# Altium schematic sheet CAPPA-DELUXE-esp.SchDoc(CAPPA-DELUXE-esp)
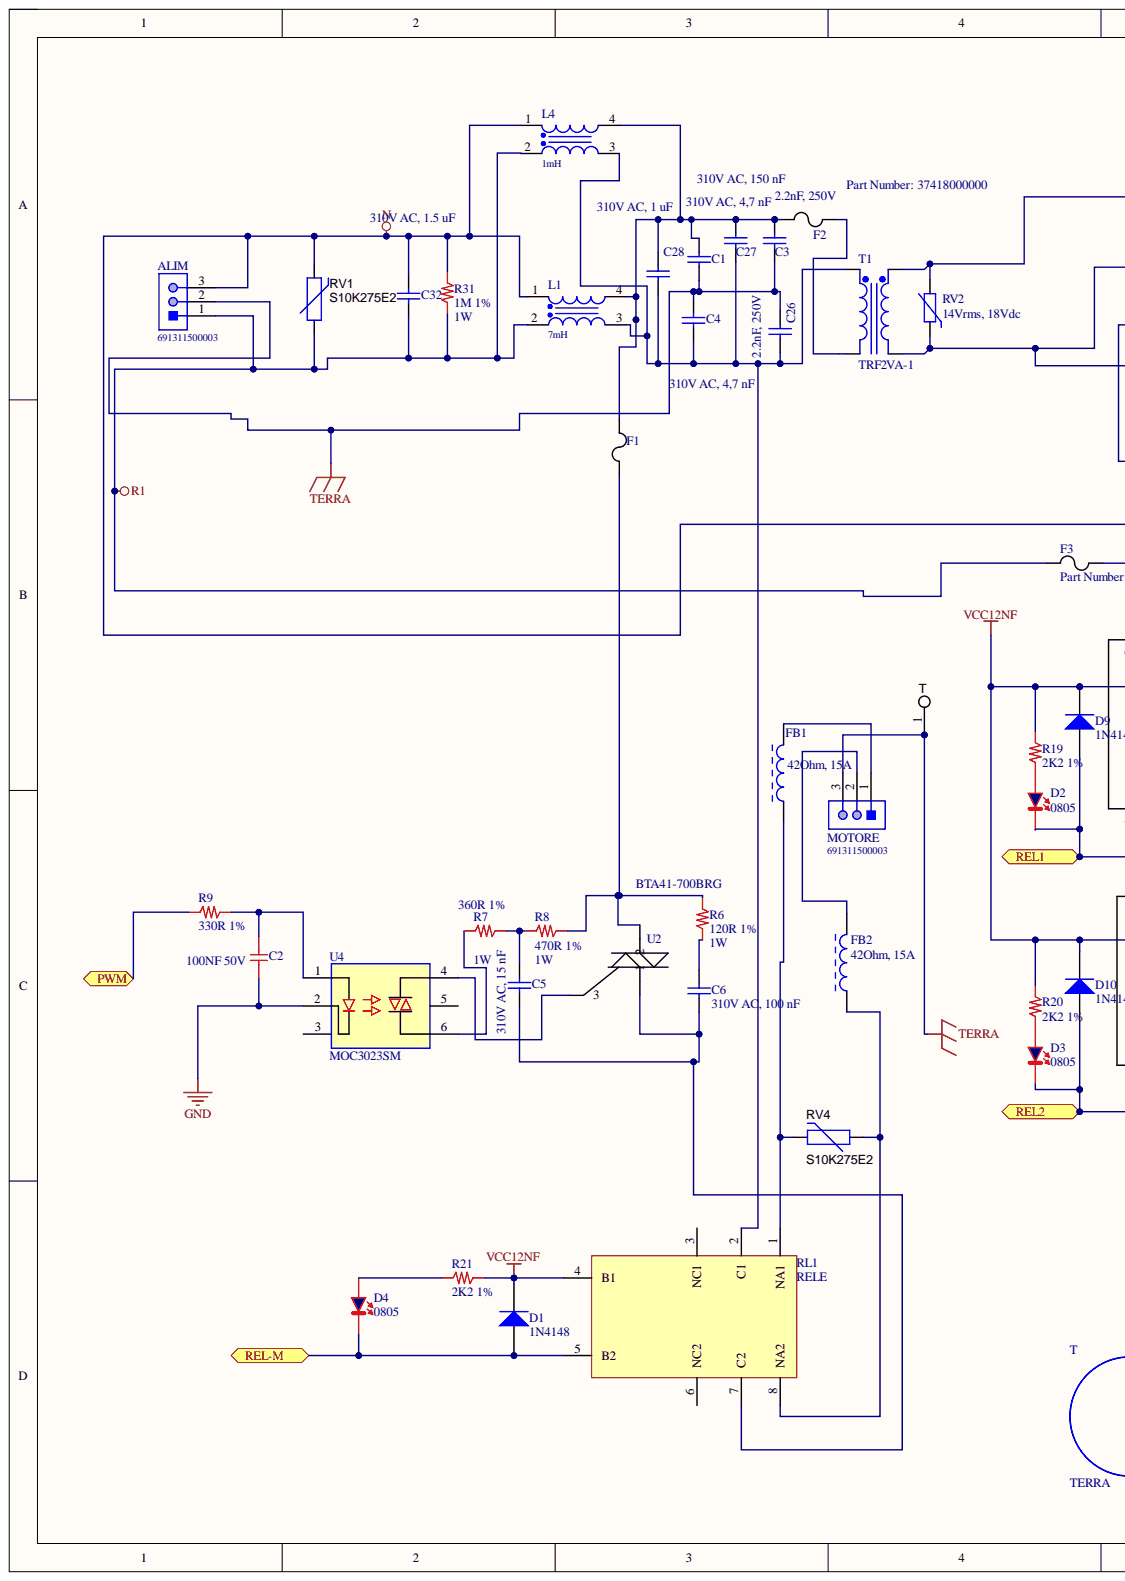
[diagram p0: CAPPA-DELUXE-esp.SchDoc, left]
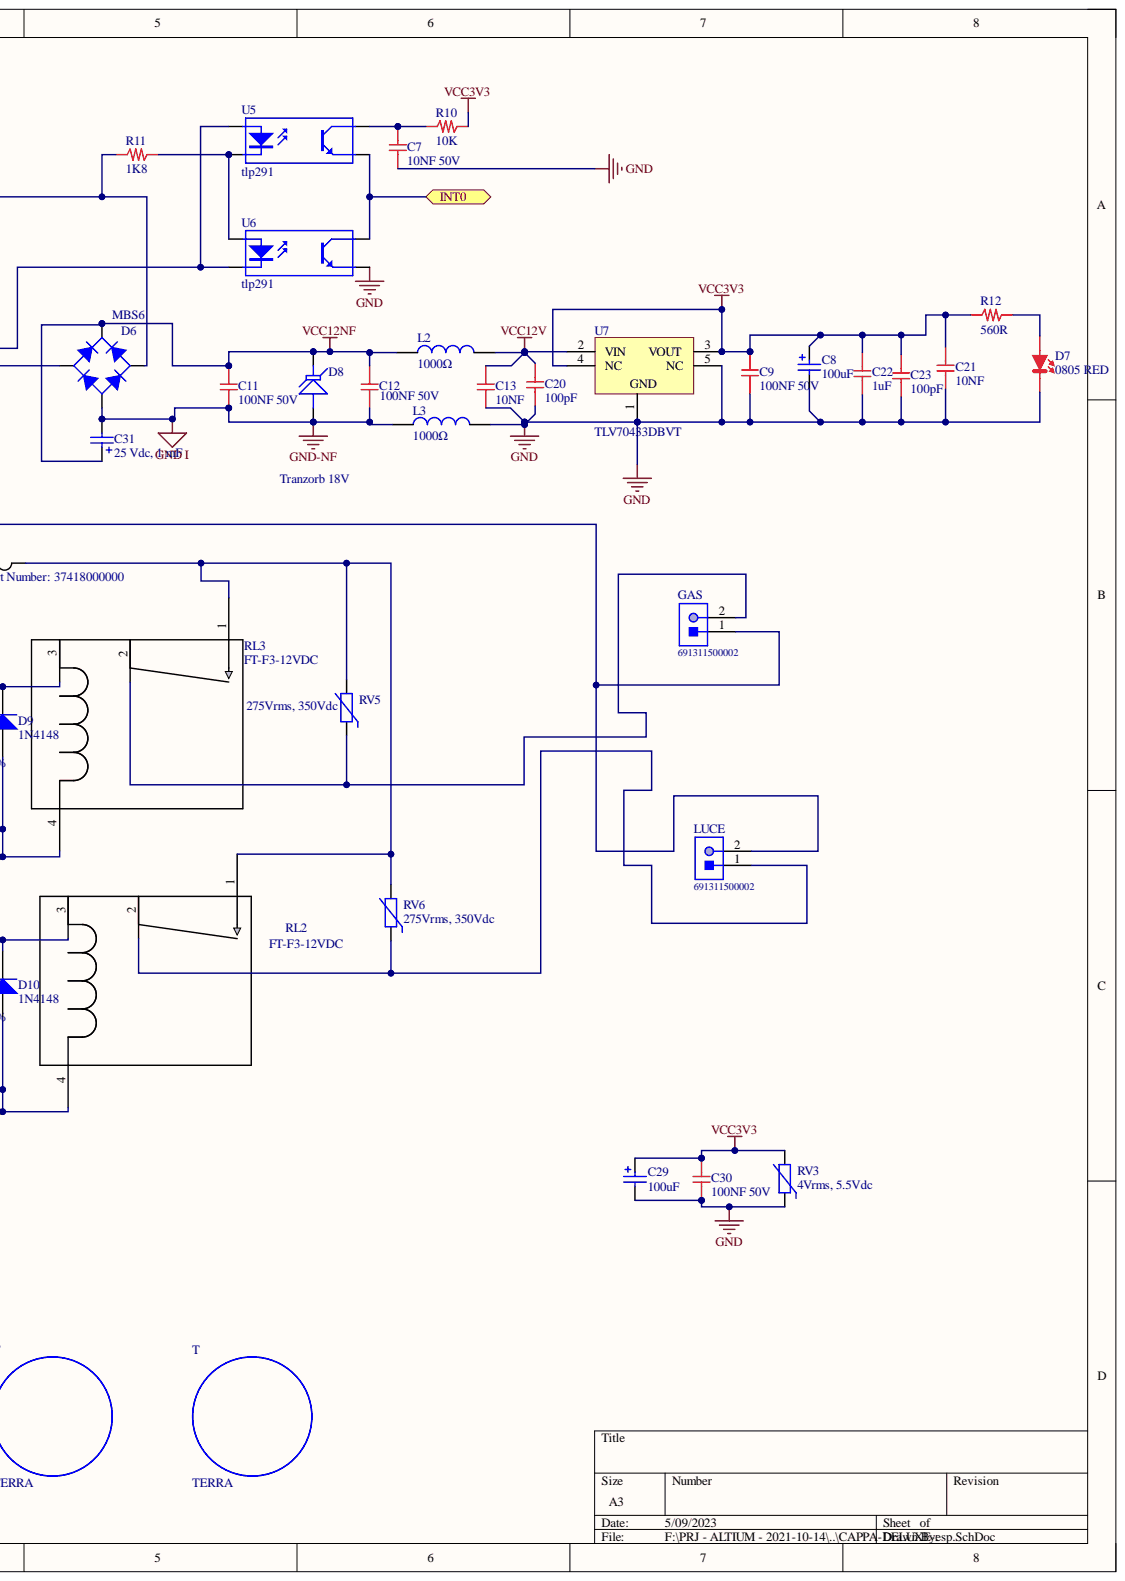
[diagram p1: CAPPA-DELUXE-esp.SchDoc, right]

## Parts
ALIM  691311500003  [691311500003]
C1  310V AC, 4,7 nF  [WCAP-FTXX_13x5x10]
C2  100NF 50V  [0603_c]
C3  2.2nF, 250V  [WCAP-CSSA_1812_H=2.5mm]
C4  310V AC, 4,7 nF  [WCAP-FTXX_13x5x10]
C5  310V AC, 15 nF  value 15 nF  [WCAP-FTXX_13x4.5x9.5]
C6  310V AC, 100 nF  value 100 nF  [WCAP-FTXX_13x7x13_CS]
C7  10NF 50V  [0603_c]
C8  value 100uF  [WCAP-ASLL_6.3x5.5]  (hidden comment: 865060343005)
C9  100NF 50V  [0603_c]
C11  100NF 50V  [0603_c]
C12  100NF 50V  [0603_c]
C13  10NF  [0603_c]
C20  100pF  [0603_c]
C21  10NF  [0603_c]
C22  1uF  [0603_c]
C23  100pF  [0603_c]
C26  2.2nF, 250V  [WCAP-CSSA_1812_H=2.5mm]
C27  310V AC, 150 nF  value 150 nF  [WCAP-FTXX_13x7x12]
C28  310V AC, 1 uF  value 1 uF  [WCAP-FTXX_26x11x20]
C29  value 100uF  [WCAP-ASLL_6.3x5.5]  (hidden comment: 865060343005)
C30  100NF 50V  [0603_c]
C31  25 Vdc, 1 mF  value 1 mF  [WCAP-ATLI_13x20x5]
C32  310V AC, 1.5 uF  value 1.5 uF  [WCAP-FTXX_26x10x21]
D1  1N4148  [1N4148]
D2  0805  [0805-BLU]
D3  0805  [0805-BLU]
D4  0805  [0805-BLU]
D6  MBS6  [HD01-T SOP4]
D7  0805 RED  [0805_Red]
D8  Tranzorb 18V  [DO-214AA]
D9  1N4148  [1N4148]
D10  1N4148  [1N4148]
F1  Part Number: 37418000000  [FUSE-S]
F2  Part Number: 37418000000  [FUSE-S]
F3  Part Number: 37418000000  [FUSE-S]
FB1  42Ohm, 15A  [WE-PBF_4030_7427934]
FB2  42Ohm, 15A  [WE-PBF_4030_7427934]
GAS  691311500002  [691311500002]
L1  7mH  [WE-CMBNC_Type L_7448040707]
L2  1000Ω  [WE-CBF_0805_High Current]
L3  1000Ω  [WE-CBF_0805_High Current]
L4  1mH  [WE-CMBNC_Type S]
LUCE  691311500002  [691311500002]
MOTORE  691311500003  [691311500003]
R6  120R 1%  Potenza: 1W  [2512_R]
R7  360R 1%  Potenza: 1W  [2512_R]
R8  470R 1%  [2512_R]
R9  330R 1%  [0805_R]
R10  10K  [0603_R]
R11  1K8  [1206_R]
R12  560R  [0603_R]
R19  2K2 1%  [0805_R]
R20  2K2 1%  [0805_R]
R21  2K2 1%  [0805_R]
R31  1M 1%  Potenza: 1W  [2512_R]
RL1  RELE  [FTR-F1R]
RL2  FT-F3-12VDC  [PAN_ALD]
RL3  FT-F3-12VDC  [PAN_ALD]
RV1  S10K275E2  [VAR_S10K275E2]
RV2  14Vrms, 18Vdc  [WE-VD_5mm_820551406]
RV3  4Vrms, 5.5Vdc  [WE-VS_0603]
RV4  S10K275E2  [VAR_S10K275E2]
RV5  275Vrms, 350Vdc  DC Operating Voltage: 350Vdc  [WE-VD_7mm_820572711]
RV6  275Vrms, 350Vdc  [WE-VD_7mm_820572711]
T  5012  [FASTOM-TERRA]  (value not drawn)
T  TERRA  [SIMBOLO-TERRA]
T  TERRA  [SIMBOLO-TERRA]
T1  TRF2VA-1  [2VA-1S]
U2  BTA41-700BRG  [TOP3_STM]
U4  MOC3023SM  [MOC3023SM]
U5  tlp291  [SO4_N]
U6  tlp291  [SO4_N]
U7  TLV70433DBVT  [SOT95P245X110-5N]

## Ports
INT0, PWM, REL1, REL2, REL-M

## Nets
GND: C2.2 C7.2 C8.2 C9.2 C13.2 C20.2 C21.2 C22.2 C23.2 C29.2 C30.2 D7.K L3.2 RV3.1 U4.2 U6.3 U7.1 U7.5(NC)
GND I: C11.1 C12.2 C31.2 D6.2 D8.2 L3.1
N: ALIM.3 C32.2 GAS.1 L1.1 L4.1 LUCE.2 R31.2 RV1.2
R1: ALIM.1 C32.1 F3.1 L1.2 L4.2 R31.1 RV1.1
TERRA: ALIM.2 C1.1 C3.1 C4.2 C26.2 MOTORE.3 T.1
VCC3V3: C8.1 C9.1 C21.1 C22.1 C23.1 C29.1 C30.1 R10.2 R12.1 RV3.2 U7.3(VOUT) U7.4(NC)
VCC12NF: C11.2 C12.1 C31.1 D1.2 D6.1 D8.1 D9.2 D10.2 L2.1 R19.2 R20.1 R21.2 RL1.4(B1) RL2.3 RL3.3
VCC12V: C13.1 C20.1 L2.2 U7.2(VIN)
INT0: U5.3 U6.4 ; port INT0
PWM: R9.1 ; port PWM
REL1: D2.K D9.1 RL3.4 ; port REL1
REL2: D3.K D10.1 RL2.4 ; port REL2
REL-M: D1.1 D4.K RL1.5(B2) ; port REL-M
NetC1_2: C1.2 C3.2 C27.2 C28.2 F1.1 F2.1 L1.4 L4.4
NetC2_1: C2.1 R9.2 U4.1
NetC4_1: C4.1 C26.1 C27.1 C28.1 L1.3 L4.3 RL1.2(C1) T1.1
NetC5_1: C5.1 C6.1 RL1.7(C2) U2.1
NetC5_2: C5.2 R7.2 R8.1
NetC6_2: C6.2 R6.2
NetC7_1: C7.1 R10.1 U5.4
NetD2_A: D2.A R19.1
NetD3_A: D3.A R20.2
NetD4_A: D4.A R21.1
NetD6_3: D6.3 R11.1 RV2.2 T1.4
NetD6_4: D6.4 RV2.1 T1.3 U5.1 U6.2
NetD7_A: D7.A R12.2
NetF1_2: F1.2 R6.1 R8.2 U2.2
NetF2_2: F2.2 T1.2
NetF3_2: F3.2 RL2.1 RL3.1 RV5.2 RV6.2
NetFB1_1: FB1.1 RL1.1(NA1) RV4.2
NetFB1_2: FB1.2 MOTORE.1
NetFB2_1: FB2.1 RL1.8(NA2) RV4.1
NetFB2_2: FB2.2 MOTORE.2
NetGAS_2: GAS.2 RL3.2 RV5.1
NetLUCE_1: LUCE.1 RL2.2 RV6.1
NetR7_1: R7.1 U4.6
NetR11_2: R11.2 U5.2 U6.1
NetU2_3: U2.3 U4.4

Not connected: RL1 3(NC1) 6(NC2); U4 3 5

## Library data of the parts
ALIM MOTORE = 691311500003: Serie 311 - 5.08mm Open Vertical PCB Header WR-TBL, 3 pin; Gender: Male; Pin Number: 3; Component Kind: Standard; ComponentLink1Description: Manufacturer; ComponentLink1URL: http://www.we-online.de; ComponentLink2Description: Datasheet; ComponentLink2URL: http://katalog.we-online.de/em/datasheet/6913115000xx.pdf; Library Name: Pluggable PCB System WR-TBL_5.08 mm.SchLib; Mounting Technology: THT; Operating Temperature: -40 to 105 degC; Packaging: Box; Part Number: 691311500003; Pitch: 5.08 mm; Published Date: July 2018; Publisher: Wurth Elektronik
C1 C4 = 890334023002: WCAP-FTXX Film Capacitors, 13x5x10mm, 310V AC, 4,7 nF CLASSE-Y; Rated Voltage: 310V AC; Value: 5.6 nF; Component Kind: Standard; ComponentLink1Description: Manufacturer; ComponentLink1URL: http://www.we-online.de; ComponentLink2Description: Datasheet; ComponentLink2URL: http://katalog.we-online.de/pbs/datasheet/890334023002.pdf; Library Name: WCAP-FTXX.SchLib; Operating Temperature: -40 °C to +105 °C; Part Number: 890334023002; Published Date: Sep. 2017; Size: 13x5x10mm; Publisher: Wurth Elektronik
C2 C7 C9 C11 C12 C30 = 100NF 50V: Cap X7R 100nF 50V Flex Terminal; Cod. Interno: COCS50665050104; Cod. Produttore: CL10B104KB8SFNC; Produttore: Samsung; Cod. DigiKey: 1276-1936-2-ND; Component Kind: Standard; Library Name: CapSMD0603.SchLib
C3 C26 = 885362211015: WCAP-CSSA X&Y Safety Ceramic Capacitors, size 1812, 2.2nF, 250VAC; Ceramic Type: X7R; Dissipation Factor: 2.5%; Isolation Resistance: 10GOhm; Safety Class: X2; Technical Reference: X7R1812222KB50IFCT10000; Capacitance: 2.2nF; Component Kind: Standard; ComponentLink1Description: Manufacturer; ComponentLink1URL: http://www.we-online.de; ComponentLink2Description: Datasheet; ComponentLink2URL: https://katalog.we-online.com/pbs/datasheet/885362211015.pdf; Height: 2.5mm +/-0.2mm; Library Name: WCAP-CSSA_1812.SchLib; Mounting Technology: SMD; Operating Temperature: -55 to +125degC; Packaging: Tape and Reel; Part Number: 885362211015; Published Date: August 2019; Rated Voltage: 250VAC; Size: 1812; Publisher: Wurth Elektronik
C5 = 890334023008: WCAP-FTXX Film Capacitors, 13x4.5x9.5mm, 310V AC, 15 nF; Rated Voltage: 310V AC; Component Kind: Standard; ComponentLink1Description: Manufacturer; ComponentLink1URL: http://www.we-online.de; ComponentLink2Description: Datasheet; ComponentLink2URL: http://katalog.we-online.de/pbs/datasheet/890334023008.pdf; Library Name: WCAP-FTXX.SchLib; Operating Temperature: -40 °C to +105 °C; Part Number: 890334023008; Published Date: Sep. 2017; Size: 13x4.5x9.5mm; Publisher: Wurth Elektronik
C6 = 890334023023CS: WCAP-FTXX Film Capacitors, 13x7x13mm, 310V AC, 100 nF; Rated Voltage: 310V AC; Value: 100 nF; Component Kind: Standard; ComponentLink1Description: Manufacturer; ComponentLink1URL: http://www.we-online.de; ComponentLink2Description: Datasheet; ComponentLink2URL: http://katalog.we-online.de/pbs/datasheet/890334023023CS.pdf; Library Name: WCAP-FTXX.SchLib; Operating Temperature: -40 °C to +105 °C; Part Number: 890334023023CS; Published Date: Sep. 2017; Size: 13x7x13mm; Publisher: Wurth Elektronik
C8 C29 = 865060343005: WCAP-ASLL Aluminum Electrolytic Capacitors, size Ф6.3x5.5mm, 16V DC, 100uF; Rated Voltage: 16V DC; Component Kind: Standard; ComponentLink1Description: Manufacturer; ComponentLink1URL: http://www.we-online.de; ComponentLink2Description: Datasheet; ComponentLink2URL: http://katalog.we-online.de/pbs/datasheet/865060343005.pdf; Library Name: WCAP-ASLL.SchLib; Life Cycle: 2000h; Operating Temperature: -55 °C up to +105 °C; Part Number: 865060343005; Published Date: June 2017; Size: Ф6.3x5.5mm; Publisher: Wurth Elektronik
C13 C21 = 10NF 50V: Cap X7R 10nF 50V; Cod. Interno: COCS 556655000103; Cod. Produttore: CL05B103KB5NNNC; Produttore: Samsung; Cod. DigiKey: 1276-1028-2-ND; Component Kind: Standard; Library Name: CapSMD0603.SchLib
C20 C23 = 100pF 50V: Cap X7R 100pF 50V; Cod. Interno: COCS??; Cod. Produttore: ??; Component Kind: Standard; Library Name: CapSMD0603.SchLib; Produttore: ??
C22 = 2.2uF 10V: 2.2 µF, 10 V, ± 10%, X5R 0603; Cod. Interno: COCS??; Cod. Produttore: 0603X225K100CT; Costo 100: 0,0436; Costo 1000: 0,0183; Produttore: WALSIN; Component Kind: Standard; ComponentLink1Description: FARNELL; ComponentLink1URL: http://it.farnell.com/walsin/0603x225k100ct/cond-mlcc-x5r-2-2uf-10v-0603-bob/dp/2495422?st=2.2uf; Library Name: CapSMD0603.SchLib
C27 = 890334023025: WCAP-FTXX Film Capacitors, 13x7x12mm, 310V AC, 150 nF; Rated Voltage: 310V AC; Value: 150 nF; Component Kind: Standard; ComponentLink1Description: Manufacturer; ComponentLink1URL: http://www.we-online.de; ComponentLink2Description: Datasheet; ComponentLink2URL: http://katalog.we-online.de/pbs/datasheet/890334023025.pdf; Library Name: WCAP-FTXX.SchLib; Operating Temperature: -40 °C to +105 °C; Part Number: 890334023025; Published Date: Sep. 2017; Size: 13x7x12mm; Publisher: Wurth Elektronik
C28 = 890334026027: WCAP-FTXX Film Capacitors, 26x11x20mm, 310V AC, 1 uF; Rated Voltage: 310V AC; Component Kind: Standard; ComponentLink1Description: Manufacturer; ComponentLink1URL: http://www.we-online.de; ComponentLink2Description: Datasheet; ComponentLink2URL: http://katalog.we-online.de/pbs/datasheet/890334026027.pdf; Library Name: WCAP-FTXX.SchLib; Operating Temperature: -40 °C to +105 °C; Part Number: 890334026027; Published Date: Sep. 2017; Size: 26x11x20mm; Publisher: Wurth Elektronik
C31 = 860080478020: WCAP-ATLI Aluminum Electrolytic Capacitors, 13x20mm, 25 Vdc, 1 mF; Rated Voltage: 25 Vdc; Component Kind: Standard; ComponentLink1Description: Manufacturer; ComponentLink1URL: http://www.we-online.de; ComponentLink2Description: Datasheet; ComponentLink2URL: http://katalog.we-online.com/pbs/datasheet/860080478020.pdf; Library Name: WCAP-ATLI.SchLib; Life Cycle: 5000h; Operating Temperature: -55 °C up to +105 °C; Part Number: 860080478020; Published Date: Sep. 2017; Size: 13x20mm; Publisher: Wurth Elektronik
C32 = 890334026030: WCAP-FTXX Film Capacitors, 26x10x21mm, 310V AC, 1.5 uF; Rated Voltage: 310V AC; Value: 1.5 uF; Component Kind: Standard; ComponentLink1Description: Manufacturer; ComponentLink1URL: http://www.we-online.de; ComponentLink2Description: Datasheet; ComponentLink2URL: http://katalog.we-online.de/pbs/datasheet/890334026030.pdf; Library Name: WCAP-FTXX.SchLib; Operating Temperature: -40 °C to +105 °C; Part Number: 890334026030; Published Date: Sep. 2017; Size: 26x10x21mm; Publisher: Wurth Elektronik
D1 D9 D10 = 1N4148: High Conductance Fast Diode; Code_JEDEC: DO-204-AH; Component Kind: Standard; ComponentLink1Description: Manufacturer Link; ComponentLink1URL: http://www.fairchildsemi.com/; ComponentLink2Description: Datasheet; ComponentLink2URL: http://www.fairchildsemi.com/ds/1N%2F1N4148.pdf; DatasheetVersion: 1997; LatestRevisionDate: 17-Jul-2002; LatestRevisionNote: Re-released for DXP Platform.; PackageDescription: Diode, Axial; Body 3.8 x 1.9 mm (LxDia. max), Lead Dia. 0.53 mm (max); PackageReference: DO-35; Published: 8-Jun-2000; Library Name: HSW.SchLib; Publisher: Altium Limited
D2-D4 = LED BLU 0805: Cod. Produttore: KPTR-3216QBC-D; Luminosità: 100mcd 20mA; Produttore: kingbright; Vf(typ): 3.3V; Component Kind: Standard; Description: LED BLU 0805; Library Name: DiodiLED.SchLib
D6 = HD01-T: Full Wave Diode Bridge; Component Kind: Standard; Library Name: HSW.SchLib; Publisher: Altium Limited
D7 = LED_ROSSO_0805: LED Rosso 0805; Cod. Interno: LEDS 2AC080RS7500; Cod. Produttore: 150080RS75000; Luminosità: 150mcd; Produttore: WE; V: 2.0V; Component Kind: Standard; Library Name: DiodiLED.SchLib
D8 = Tranzorb: Transient Voltage Suppression (TVS) Diode; Component Kind: Standard; LatestRevisionDate: 17-Jul-2002; LatestRevisionNote: Re-released for DXP Platform.; PackageDescription: Axial Lead Diode; PackageReference: DO-7; Published: 24-Mar-1999; Library Name: HSW.SchLib; Publisher: Altium Limited
F1-F3 = FUSE-S: No Description Available; Built_By: EMA_UL_Team; Type: DEV; Vendor: Littelfuse Inc; Component Kind: Standard; Library Name: FUSE-S.SchLib; Manufacturer_Part_Number: 37418000000; RefDes: RefDes; Value: Value; Copyright: Copyright (C) 2018 Accelerated Designs. All rights reserved
FB1 FB2 = 7427934: WE-PBF Flat Wire High Current SMT Ferrite Bead, size 4030, 42Ohm, 15A; Manufacturer: Wurth Elektronik; DC Resistance max.: 0.4mOhm; Impedance @25MHz: 28Ohm; Type: Not glued; Component Kind: Standard; ComponentLink1Description: Manufacturer; ComponentLink1URL: http://www.we-online.de; ComponentLink2Description: Datasheet; ComponentLink2URL: https://www.we-online.com/catalog/datasheet/7427934.pdf; Height: 2.5mm; Impedance @100MHz: 42Ohm; Length: 4.8mm; Library Name: WE-PBF_4030.SchLib; Manufacturer Part Number: 7427934; Mounting Technology: SMT; Operating Temperature: -55 to +125degC; Packaging: Tape and Reel; Published Date: April 2020; Rated Current: 15A; Size: 4030; Width: 3mm
GAS LUCE = 691311500002: Serie 311 - 5.08mm Open Vertical PCB Header WR-TBL, 2 pin; Gender: Male; Pin Number: 2; Component Kind: Standard; ComponentLink1Description: Manufacturer; ComponentLink1URL: http://www.we-online.de; ComponentLink2Description: Datasheet; ComponentLink2URL: http://katalog.we-online.de/em/datasheet/6913115000xx.pdf; Library Name: Pluggable PCB System WR-TBL_5.08 mm.SchLib; Mounting Technology: THT; Operating Temperature: -40 to 105 degC; Packaging: Box; Part Number: 691311500002; Pitch: 5.08 mm; Published Date: July 2018; Publisher: Wurth Elektronik
L1 = 7448040707: WE-CMBNC Common Mode Power Line Choke Nanocrystalline, Type L, 7mH, 7A, 250VAC; Manufacturer: Wurth Elektronik; DC Resistance max.: 20mOhm; Insulation Test Voltage: 1500VAC; Component Kind: Standard; ComponentLink1Description: Manufacturer; ComponentLink1URL: http://www.we-online.de; ComponentLink2Description: Datasheet; ComponentLink2URL: https://www.we-online.com/catalog/datasheet/7448040707.pdf; Inductance: 7mH; Library Name: WE-CMBNC_Type L.SchLib; Manufacturer Part Number: 7448040707; Mounting Technology: THT; Operating Temperature: -40 to +125degC; Packaging: Carton; Published Date: April 2020; Rated Current: 7A; Rated Voltage: 250VAC; Size: Type L
L2 L3 = WE-CBF, 742792096: WE-CBF SMD EMI Suppression Ferrite Bead, 0805, 1000Ω; Type: High Current; Component Kind: Standard; ComponentLink1Description: Manufacturer; ComponentLink1URL: http://www.we-online.de; ComponentLink2Description: Datasheet; ComponentLink2URL: http://katalog.we-online.de/pbs/datasheet/742792096.pdf; Library Name: WE-CBF_0805.SchLib; PartNumber: 742792096; PublishedDate: Aug 2016; Size: 0805; Value: 1000Ω; Publisher: Wurth Elektronik
L4 = 7448027001: WE-CMBNC Common Mode Power Line Choke Nanocrystalline, Type S, 1mH, 7A, 250VAC; Manufacturer: Wurth Elektronik; DC Resistance max.: 6mOhm; Insulation Test Voltage: 1500VAC; Component Kind: Standard; ComponentLink1Description: Manufacturer; ComponentLink1URL: http://www.we-online.de; ComponentLink2Description: Datasheet; ComponentLink2URL: https://www.we-online.com/catalog/datasheet/7448027001.pdf; Inductance: 1mH; Library Name: WE-CMBNC_Type S.SchLib; Manufacturer Part Number: 7448027001; Mounting Technology: THT; Operating Temperature: -40 to +125degC; Packaging: Carton; Published Date: April 2020; Rated Current: 7A; Rated Voltage: 250VAC; Size: Type S
R6-R8 R31 = 120R 1% 1W: Res Thick Film 120R 1W 1%; Cod. Interno: RESS 4190310A1200; Cod. Produttore: CRCW2512120RFKEG; Produttore: Vishay Dale; Cod. DigiKey: 541-120AFCT-ND; Component Kind: Standard; Library Name: ResSMD2512.SchLib
  R8: Potenza: 1W
R9 = 300R 1%: Res Film Spesso 100mW 1M 1%; Cod. Interno: ??; Cod. Produttore: ERA6AEB301V; Produttore: Panasonic; V nominale: 100V; Cod. Farnell: 1670221; Component Kind: Standard; Library Name: ResSMD0805.SchLib; Potenza: 100mW
R10 = 10K: Res Film Spesso 1/16W 10K 5%; Cod. Interno: RESS4166556B0103??; Cod. Produttore: ??; Component Kind: Standard; Library Name: ResSMD0603.SchLib; Produttore: ??
R11 = 1K8: 1K8 1206 1/4W; Case: 1206; Component Kind: Standard; Library Name: ResSMD1206.SchLib
R12 = 560R: Res Film Spesso 1/16W 560R 5%; Cod. Interno: RESS 4166556B0561; Component Kind: Standard; Library Name: ResSMD0603.SchLib
R19-R21 = 2K2 1%: Res Film Spesso 0805 1/2W 2K2 1%; Cod. Interno: ??; Component Kind: Standard; Library Name: ResSMD0805.SchLib
RL1 = FTR-F1R: RELE 8A 2NA; Component Kind: Standard; Library Name: HSW.SchLib
RL2 RL3 = ALDP106W: No Description Available; Built_By: EMA_UL_Team; Vendor: Panasonic; Component Kind: Standard; Manufacturer_Part_Number: ALDP106W; RefDes: RefDes; Type: DEV; Value: Value; Copyright: Copyright (C) 2018 Accelerated Designs. All rights reserved; Library Name: FTR-F3.SchLib
RV1 RV4 = S10K275E2: Varistor 275V RMS 10MM Radial, TH; Manufacturer: EPCOS Inc; SymbolCreator: a0412235; Component Kind: Standard; ComponentLink1Description: Datasheet URL; ComponentLink1URL: http://www.epcos.com/inf/70/db/var_08/SIOV_Leaded_AdvanceD.pdf; LatestRevisionDate: 2013-02-24; MountType: TH; PackageReference: 10mm Radial; PartNumber: S10K275E2; RoHS: Y; Supplier 1: Digi-Key; Supplier Part Number 1: 495-1433-ND; SymbolVersion: 2012-06-04; Library Name: HSW.SchLib; Publisher: Texas Instruments
RV2 = 820551406: WE-VD Disk Varistor, size 5mm, 14Vrms, 18Vdc; (Channel) Input Capacitance: 1130pF; (Reverse) Breakdown Voltage: 22V; (Reverse) Peak Pulse Current: 100A; Clamping Voltage: 48V; Energy Absorbtion: 0.7J; Power Dissipation: 0.01W; AC Operating Voltage: 14Vrms; Component Kind: Standard; ComponentLink1Description: Manufacturer; ComponentLink1URL: http://www.we-online.de; ComponentLink2Description: Datasheet; ComponentLink2URL: https://katalog.we-online.com/pbs/datasheet/820551406.pdf; DC Operating Voltage: 18Vdc; Mounting Technology: THT; Operating Temperature: -40 to +85degC; Packaging: Tape and Reel; Part Number: 820551406; Published Date: March 2019; Size: 5mm; Library Name: MOV_5mm.SchLib; Publisher: Wurth Elektronik
RV3 = 82536040: WE-VS SMD Varistor, size 0603, 4Vrms, 5.5Vdc; Clamping Voltage: 21V; Energy Dissipation: 0.1J; Varistor Voltage: 8V; Withstanding Surge Current: 30A; AC Operating Voltage: 4Vrms; Component Kind: Standard; ComponentLink1Description: Manufacturer; ComponentLink1URL: http://www.we-online.de; ComponentLink2Description: Datasheet; ComponentLink2URL: https://katalog.we-online.com/pbs/datasheet/82536040.pdf; DC Operating Voltage: 5.5Vdc; Library Name: WE-VS_0603.SchLib; Mounting Technology: SMT; Operating Temperature: -40 to +85degC; Packaging: Tape and Reel; Part Number: 82536040; Published Date: March 2019; Size: 0603; Publisher: Wurth Elektronik
RV5 RV6 = 820572711: WE-VD Disk Varistor, size 7mm, 275Vrms, 350Vdc; (Channel) Input Capacitance: 105pF; (Reverse) Breakdown Voltage: 430V; (Reverse) Peak Pulse Current: 1200A; Clamping Voltage: 710V; Energy Absorbtion: 33J; Power Dissipation: 0.25W; AC Operating Voltage: 275Vrms; Component Kind: Standard; ComponentLink1Description: Manufacturer; ComponentLink1URL: http://www.we-online.de; ComponentLink2Description: Datasheet; ComponentLink2URL: https://katalog.we-online.com/pbs/datasheet/820572711.pdf; Mounting Technology: THT; Operating Temperature: -40 to +85degC; Packaging: Tape and Reel; Part Number: 820572711; Published Date: March 2019; Size: 7mm; Library Name: MOV_7mm.SchLib; Publisher: Wurth Elektronik
  RV6: DC Operating Voltage: 350Vdc
T = TERRA: Test Point, Multipurpose, White, TH; Manufacturer: Keystone; CompType: Test Point Loop; ContactFinish: Silver; Color: White; Component Kind: Standard; ComponentLink1Description: Datasheet URL; ComponentLink1URL: http://www.keyelco.com/userAssets/file/M60-2p60.pdf; LatestRevisionDate: 2017-01-19; MountType: TH; PackageReference: White Multipurpose Testpoint; PartNumber: 5012; RoHS: Y; Supplier 1: Digi-Key; Supplier 2: Mouser; Supplier Part Number 1: 36-5012-ND; Supplier Part Number 2: 534-5012; SymbolVersion: 2008-04-04; Library Name: HSW.SchLib; Publisher: Texas Instruments
T T = SIMBOLO-TERRA: Component Kind: Standard; Library Name: HSW.SchLib
T1 = TRF2VA-1: Center-Tapped Transformer (Coupled Inductor Model); Component Kind: Standard; LatestRevisionDate: 17-Jul-2002; LatestRevisionNote: Re-released for DXP Platform.; PackageDescription: Transformer; 5 Leads; PackageReference: TRF_5; Published: 8-Jun-2000; Library Name: HSW.SchLib; Publisher: Altium Limited
U2 = BTA41-700BRG: TRIAC 40A 700V; Built_By: EMA_UL_Team; SourceLibrary: StMicro_2015-03-30; Vendor: STMicroelectronics; Component Kind: Standard; Manufacturer_Part_Number: BTA41-700BRG; RefDes: RefDes; Type: DEV; Copyright: Copyright (C) 2018 Accelerated Designs. All rights reserved; Library Name: HSW.SchLib
U4 = MOC3023SM: Fotoaccoppiatore, Uscita Triac,  DIP6-SMD, 5.3 kV, Non Zero Crossing; Costo 100: 0.274; Costo 1000: 0.185; Produttore: ON SEMICONDUCTOR; Cod. Farnell: 2322550; Cod. Prodotto: MOC3023SM; Component Kind: Standard; Library Name: Varie.SchLib
U5 U6 = tlp291: 4-Pin Phototransistor Optocoupler; Component Kind: Standard; DatasheetVersion: Nov-2003; LatestRevisionDate: 04-Mar-2005; LatestRevisionNote: Sch pins 3 & 4 Swapped.; PackageReference: DIP-4; Published: 24-Mar-1999; Library Name: HSW.SchLib; Publisher: Altium Limited
U7 = TLV70433DBVT: 3-Terminal Positive Voltage Regulator; Code_IPC: SOIC127P600-8; Component Kind: Standard; ComponentLink1Description: Manufacturer Link; ComponentLink1URL: http://www.ti.com/; DatasheetVersion: Oct-1988; LatestRevisionDate: 13-Apr-2006; LatestRevisionNote: IPC-7351 Footprint Added.; PackageDescription: 8-Pin Small Outline Integrated Circuit 1.27 mm Pitch; PackageReference: D008; PackageVersion: Jan-1998; Published: 15-Apr-2002; Library Name: HSW.SchLib; Publisher: Altium Limited
